# Altium schematic sheet lemoniot_battery.SchDoc
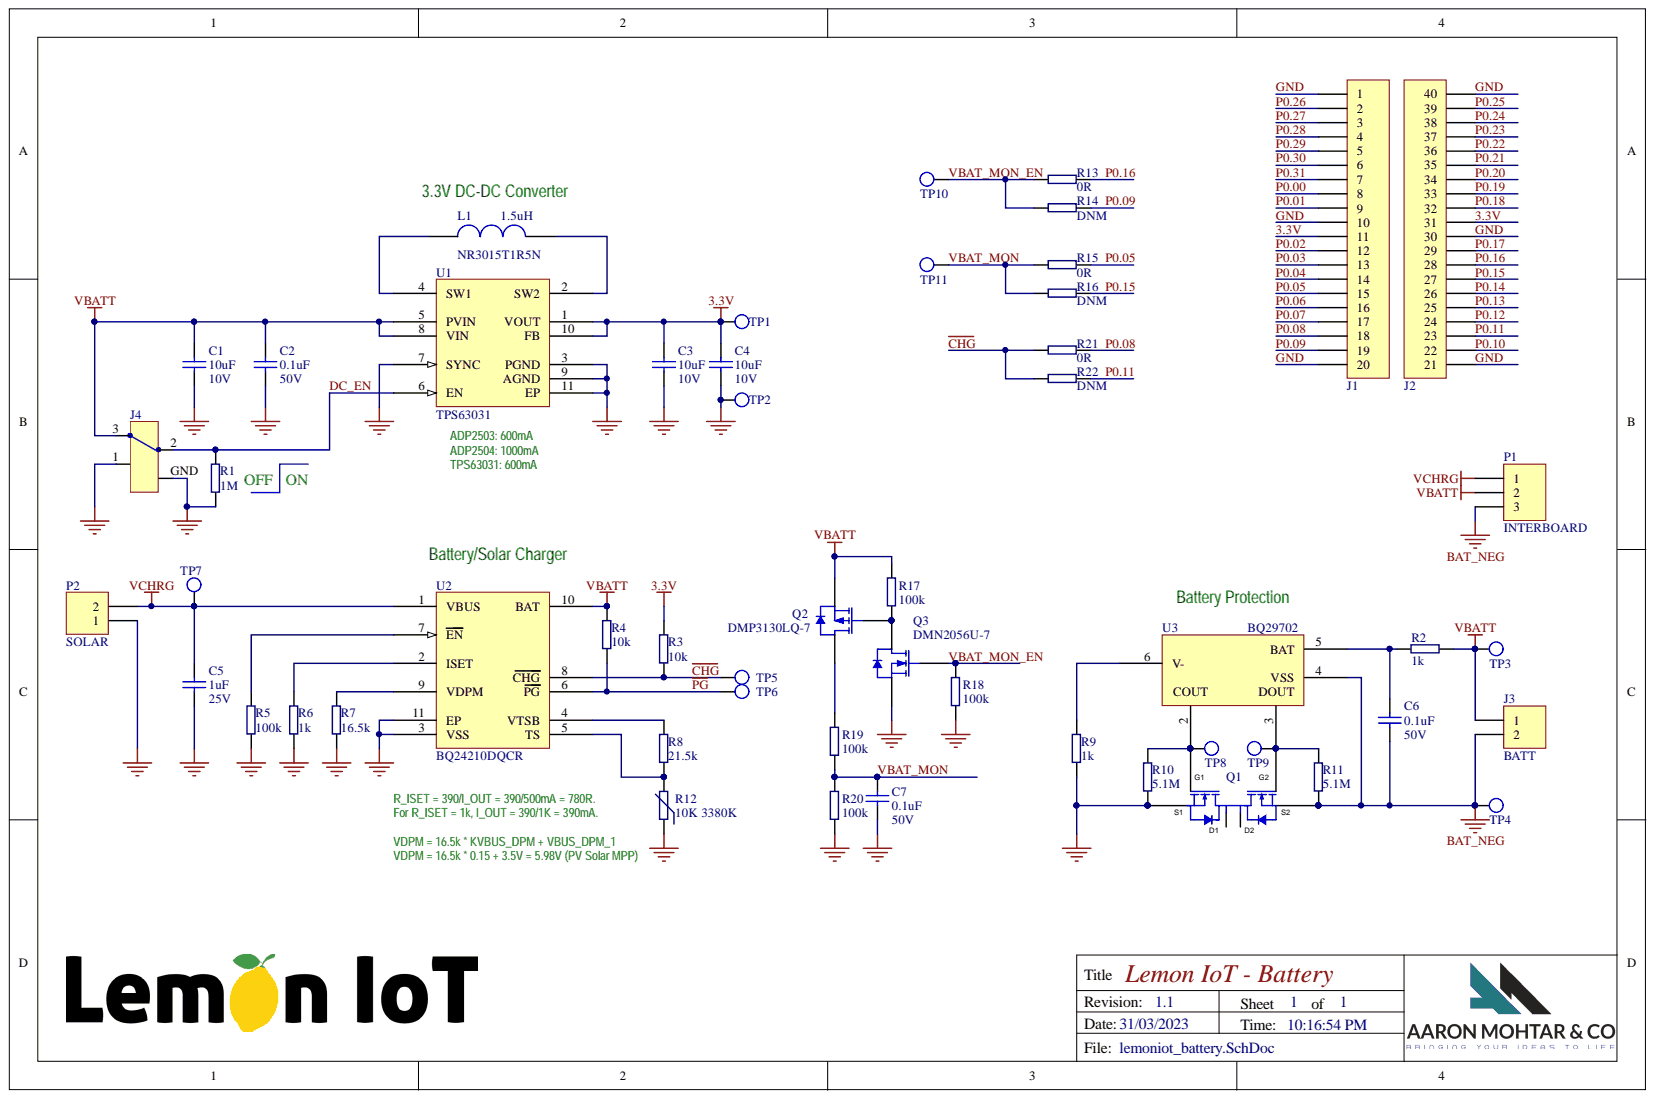
[diagram p0: lemoniot_battery.SchDoc]

## Parts
C1  10uF  Voltage: 10V  [CAPC0603(1608)100_N]
C2  0.1uF  Voltage: 50V  [CAPC0603(1608)100_N]
C3  10uF  Voltage: 10V  [CAPC0603(1608)100_N]
C4  10uF  Voltage: 10V  [CAPC0603(1608)100_N]
C5  1uF  Voltage: 25V  [CAPC0603(1608)100_N]
C6  0.1uF  Voltage: 50V  [CAPC0603(1608)100_N]
C7  value 0.1uF  Voltage: 50V  [CAPC0603(1608)100_N]  (hidden comment: 0.1uF 0603 50V)
J1  CONN RCPT 20POS 0.1 GOLD PCB  [SSQ-120-03-G-S]  (value not drawn)
J2  HDR 2.54 VERT SIL 20POS (B)  [SSQ-120-03-G-S-(B)]  (value not drawn)
J3  BATT  [S2B-PH-SM4-TB(LF)(SN)-2_V]
J4  SWITCH SLIDE SPDT 200MA 30V  [1825232-1]  (value not drawn)
L1  1.5uH  PartNumber: NR3015T1R5N  [TAYO-IND0315_V]
P1  INTERBOARD  [Conn header 3pin 0.1" TH RA (Molex)]
P2  SOLAR  [MOLEX SL 0705530001]
Q1  DMG9926  [FP-SOT26_SC74R-IPC_B]  (value not drawn)
Q2  DMP3130LQ-7  [FP-SOT23-IPC_B]
Q3  DMN2056U-7  [FP-SOT23-IPC_B]
R1  1M  [RESC0603(1608)_N]
R2  1k  [RESC0603(1608)_N]
R3  10k  [RESC0603(1608)_N]
R4  10k  [RESC0603(1608)_N]
R5  100k  [RESC0603(1608)_N]
R6  1k  [RESC0603(1608)_N]
R7  16.5k  [RESC0603(1608)_N]
R8  21.5k  [RESC0603(1608)_N]
R9  1k  [RESC0603(1608)_N]
R10  5.1M  [RESC0603(1608)_N]
R11  5.1M  [RESC0603(1608)_N]
R12  10K 3380K  [RESC0603(1608)_N]
R13  value 0R  [RESC0603(1608)_N]  (hidden comment: RES 0R 0603)
R14  value DNM  [RESC0603(1608)_N]  (hidden comment: RES 0R 0603)  (no BOM)
R15  value 0R  [RESC0603(1608)_N]  (hidden comment: RES 0R 0603)
R16  value DNM  [RESC0603(1608)_N]  (hidden comment: RES 0R 0603)  (no BOM)
R17  value 100k  [RESC0603(1608)_N]  (hidden comment: 100K 0603)
R18  value 100k  [RESC0603(1608)_N]  (hidden comment: 100K 0603)
R19  value 100k  [RESC0603(1608)_N]  (hidden comment: 100K 0603)
R20  value 100k  [RESC0603(1608)_N]  (hidden comment: 100K 0603)
R21  value 0R  [RESC0603(1608)_N]  (hidden comment: RES 0R 0603)
R22  value DNM  [RESC0603(1608)_N]  (hidden comment: RES 0R 0603)  (no BOM)
TP1  TestPoint_1  [Test Point - Small]  (value not drawn)  (no BOM)
TP2  TestPoint_1  [Test Point - Small]  (value not drawn)  (no BOM)
TP3  TestPoint_1  [Test Point - Small]  (value not drawn)  (no BOM)
TP4  TestPoint_1  [Test Point - Small]  (value not drawn)  (no BOM)
TP5  TestPoint_1  [Test Point - Small]  (value not drawn)  (no BOM)
TP6  TestPoint_1  [Test Point - Small]  (value not drawn)  (no BOM)
TP7  TestPoint_1  [Test Point - Small]  (value not drawn)  (no BOM)
TP8  TestPoint_1  [Test Point - Small]  (value not drawn)  (no BOM)
TP9  TestPoint_1  [Test Point - Small]  (value not drawn)  (no BOM)
TP10  TestPoint_1  [Test Point - Small]  (value not drawn)  (no BOM)
TP11  TestPoint_1  [Test Point - Small]  (value not drawn)  (no BOM)
U1  TPS63031  [ADI-CP-10-9_V]
U2  BQ24210DQCR  [SON50P300X200X80-11N]
U3  BQ29702  [FP-DSE0006A-IPC_C]

## Nets
BAT_NEG: C6.1 J3.2 P1.3 Q1.3 R11.1 TP4.0 U3.4(VSS)
VBATT: C1.2 C2.2 J3.1 J4.3 P1.2 Q2.2 R2.2 R4.2 R17.2 TP3.0 U1.5(PVIN) U1.8(VIN) U2.10(BAT)
VCHRG: C5.2 P1.1 P2.2 TP7.0 U2.1(VBUS)
3.3V: C3.2 C4.2 J1.11 J2.31 R3.2 TP1.0 U1.1(VOUT) U1.10(FB)
~{CHG}: R3.1 R21.1 R22.1 TP5.0 U2.8(CHG)
DC_EN: J4.2 R1.2 U1.6(EN)
GND: C1.1 C2.1 C3.1 C4.1 C5.1 C7.1 J1.1 J1.10 J1.20 J2.21 J2.30 J2.40 J4.1 J4.GND P2.1 Q1.1 Q3.2 R1.1 R5.1 R6.1 R7.1 R9.1 R10.1 R12.1 R18.1 R20.1 TP2.0 U1.3(PGND) U1.7(SYNC) U1.9(AGND) U1.11(EP) U2.3(VSS) U2.11(EP)
P0.00: J1.8
P0.01: J1.9
P0.02: J1.12
P0.03: J1.13
P0.04: J1.14
P0.05: J1.15 R15.2
P0.06: J1.16
P0.07: J1.17
P0.08: J1.18 R21.2
P0.09: J1.19 R14.2
P0.10: J2.22
P0.11: J2.23 R22.2
P0.12: J2.24
P0.13: J2.25
P0.14: J2.26
P0.15: J2.27 R16.2
P0.16: J2.28 R13.2
P0.17: J2.29
P0.18: J2.32
P0.19: J2.33
P0.20: J2.34
P0.21: J2.35
P0.22: J2.36
P0.23: J2.37
P0.24: J2.38
P0.25: J2.39
P0.26: J1.2
P0.27: J1.3
P0.28: J1.4
P0.29: J1.5
P0.30: J1.6
P0.31: J1.7
~{PG}: R4.1 TP6.0 U2.6(PG)
VBAT_MON: C7.2 R15.1 R16.1 R19.1 R20.2 TP11.0
VBAT_MON_EN: Q3.1 R13.1 R14.1 R18.2 TP10.0
NetC6_2: C6.2 R2.1 U3.5(BAT)
NetL1_1: L1.1 U1.4(SW1)
NetL1_2: L1.2 U1.2(SW2)
NetQ1_4: Q1.4 R11.2 TP9.0 U3.3
NetQ1_6: Q1.6 R10.2 TP8.0 U3.2
NetQ2_1: Q2.1 Q3.3 R17.1
NetQ2_3: Q2.3 R19.2
NetR5_2: R5.2 U2.7(EN)
NetR6_2: R6.2 U2.2(ISET)
NetR7_2: R7.2 U2.9(VDPM)
NetR8_1: R8.1 R12.2 U2.5(TS)
NetR8_2: R8.2 U2.4(VTSB)
NetR9_2: R9.2 U3.6(V-)

Not connected: Q1 2 5

## Library data of the parts
C1 C3 C4 = CAP 10uF 10V 0603: CAP CER 10UF 10V X5R 0603; Manufacturer: Taiyo Yuden; PartNumber: LMK107BBJ106MALT; DK code: 587-3258-1-ND; Component Kind: Standard; Library Name: Passives.SchLib; Operating temperature: -55°C ~ 85°C; PackageDescription: 0603; Temperature coefficient: X5R; Value: 10uF
C2 C6 = CAP 0.1uF 50V 0603: CAP CER 0.1UF 50V X7R 0603; Manufacturer: AVX Corporation; PartNumber: 06035C104KAT2A; DK code: 478-5052-1-ND; Component Kind: Standard; Library Name: Passives.SchLib; Operating temperature: -55°C ~ 125°C; PackageDescription: 0603; Temperature coefficient: X7R; Value: 0.1uF
C5 = CAP 1uF 25V 0603: CAP CER 1UF 25V X7R 0603; Manufacturer: Samsung Electro-Mechanics; PartNumber: CL10B105KA8NNNC; DK code: 1276-1184-1-ND; Component Kind: Standard; Library Name: Passives.SchLib; Operating temperature: -55°C ~ 125°C; PackageDescription: 0603; Temperature coefficient: X7R; Value: 1uF
C7 = CAP 0.1uF 25V 0603: CAP CER 0.1UF 50V X7R 0603; Manufacturer: AVX Corporation; PartNumber: 06035C104KAT2A; DK code: 478-5052-1-ND; Component Kind: Standard; Operating temperature: -55°C ~ 125°C; PackageDescription: 0603; Temperature coefficient: X7R; Library Name: TranspIR.SchLib
J1 = HDR 2.54 VERT SIL 20POS: Manufacturer: Samtec Inc.; DK code: SAM1198-20-ND; Component Kind: Standard; Description: CONN RCPT 20POS 0.1 GOLD PCB; Library Name: connectors.IntLib; PartNumber: SSQ-120-03-G-S
J2 = HDR 2.54 VERT SIL 20POS (B): CONN HEADER VERT 20POS 2.54MM; Manufacturer: Harwin Inc.; PartNumber: M20-9992046; DK code: 952-3306-ND; Component Kind: Standard; Library Name: connectors.IntLib
J3 = JST CONN HDR SMD R/A 2POS 2MM: CONN HEADER VERT 2POS 2.54MM; Manufacturer: JST Sales America Inc.; PartNumber: S2B-PH-SM4-TB(LF)(SN); DK code: 455-1749-1-ND; Component Kind: Standard; Library Name: Connectors.SchLib
J4 = 1825232-1: Slide Switch SPDT Through Hole, Right Angle; Manufacturer: TE Connectivity; DK code: A107673-ND; Component Kind: Standard; Library Name: battery.SchLib; PartNumber: 1825232-1
L1 = IND 1.5uH 1.82A: FIXED IND 1.5UH 1.82A 48MOHM SMD; Manufacturer: Taiyo Yuden; DK code: 587-2617-1-ND; Component Kind: Standard; Library Name: Passives.SchLib; Value: 1.5uH
P1 = CONN RCPT 3POS: CONN RCPT 3POS 0.1 GOLD; Manufacturer: Samtec Inc.; PartNumber: SSQ-120-03-G-S; DK code: SAM1198-20-ND; Component Kind: Standard; Library Name: lemoniot_battery.SchLib
P2 = MOLEX SL HDR 2.54 RA SIL 2POS: CONN HEADER R/A 2POS 2.54MM; Manufacturer: Molex, LLC; PartNumber: 0705530001; DK code: WM4900-ND; Component Kind: Standard; Library Name: Connectors.SchLib
Q1 = DMG9926UDM: MOSFET 2N-CH 20V 4.2A SOT-26; Manufacturer: Diodes Incorporated; PartNumber: DMG9926UDM-7; DK code: DMG9926UDMDITR-ND; Component Kind: Standard; Library Name: Discretes.SchLib; Package: SOT-26
Q2 = DMP3130LQ-7: MOSFET P-CH 30V 3.5A SOT23; Manufacturer: Diodes Incorporated; DK code: DMP3130LQ-7DICT-ND; Component Kind: Standard; PackageDescription: SOT23; PartNumber: DMP3130LQ-7; Library Name: Athena-TranspIR.SchLib
Q3 = DMN2056U-7: MOSFET N-CHANNEL 20V 4A SOT23-3; Manufacturer: Diodes Incorporated; DK code: DMN2056U-7DICT-ND; Component Kind: Standard; PackageDescription: SOT23-3; PartNumber: DMN2056U-7; Library Name: Athena-TranspIR.SchLib
R1 = RES 10K 0603: Tolerance: 1%; Component Kind: Standard; Library Name: Passives.SchLib; PackageDescription: 0603; Value: 1M
R2 R6 R9 = RES 1K 0603: RES SMD 1K OHM 1% 1/10W 0603; Manufacturer: Yageo; PartNumber: RC0603FR-071KL; DK code: 311-1.00KHRCT-ND; Component Kind: Standard; Library Name: Passives.SchLib; PackageDescription: 0603; Tolerance: 1%; Value: 1k
R3 R4 = RES 10K 0603: RES SMD 10K OHM 1% 1/10W 0603; Manufacturer: Yageo; PartNumber: RC0603FR-0710KL; DK code: 311-10.0KHRCT-ND; Component Kind: Standard; Library Name: Passives.SchLib; PackageDescription: 0603; Tolerance: 1%; Value: 10k
R5 = RES 100K 0603: RES SMD 100K OHM 1% 1/10W 0603; Manufacturer: Yageo; PartNumber: RC0603FR-07100KL; DK code: 311-100KHRCT-ND; Component Kind: Standard; Library Name: Passives.SchLib; PackageDescription: 0603; Tolerance: 1%; Value: 100k
R7 = RES 16.5K 0603: RES SMD 16.5K OHM 1% 1/10W 0603; Manufacturer: Yageo; PartNumber: RC0603FR-0716K5L; DK code: 311-16.5KHRCT-ND; Component Kind: Standard; Library Name: Passives.SchLib; PackageDescription: 0603; Tolerance: 1%; Value: 16.5k
R8 = RES 21.5K 0603: RES SMD 21.5K OHM 1% 1/10W 0603; Manufacturer: Yageo; PartNumber: RC0603FR-0721K5L; DK code: 311-21.5KHRCT-ND; Component Kind: Standard; Library Name: Passives.SchLib; PackageDescription: 0603; Tolerance: 1%; Value: 21.5k
R10 R11 = RES 5.1M 0603: RES SMD 5.1M OHM 1% 1/10W 0603; Manufacturer: Yageo; PartNumber: RC0603FR-075M1L; DK code: 13-RC0603FR-075M1LCT-ND; Component Kind: Standard; Library Name: Passives.SchLib; PackageDescription: 0603; Tolerance: 1%; Value: 5.1M
R12 = THERMISTOR NTC 10K 0603: THERMISTOR NTC 10KOHM 3380K 0603; Manufacturer: Murata Electronics; PartNumber: NCU18XH103F60RB; DK code: 490-16279-1-ND; Tolerance: 1%; Component Kind: Standard; Library Name: Passives.SchLib; PackageDescription: 0603; Value: 10K 3380K
R13-R16 R21 R22 = RES 0R 0603: RES SMD 0 OHM JUMPER 1/10W 0603; Manufacturer: Bourns Inc.; PartNumber: CR0603-J/-000ELF; DK code: CR0603-J/-000ELFTR-ND; PackageDescription: 0603; Library Name: amco-PnpLibrary.IntLib
  R13 R15 R21: Component Kind: Standard
R17-R20 = RES 100K 0603: RES SMD 100K OHM 1% 1/10W 0603; Manufacturer: Bourns Inc.; PartNumber: CR0603-FX-1003ELF; DK code: CR0603-FX-1003ELFCT-ND; Component Kind: Standard; PackageDescription: 0603; Tolerance: 1%; Library Name: TranspIR.SchLib
TP1-TP11 = TestPoint_1: Test Point; Library Name: other.IntLib
U1 = TPS63031: IC REG BCK BST 3.3V 900MA 10SON; Manufacturer: Texas Instruments; PartNumber: TPS63031DSKR; DK code: 296-39461-2-ND; Component Kind: Standard; Library Name: ICs.SchLib; PackageDescription: 10-WFDFN
U2 = BQ24210DQCR: IC BATT CHG LI-ION 1CELL 10WSON; Manufacturer: Texas Instruments; DK code: 296-BQ24210DQCRTR-ND; Component Kind: Standard; Package: WSON-10; PartNumber: BQ24210DQCR; Library Name: IntergratedCircuits.SchLib
U3 = BQ29702DSET: IC BATT PROT LI-ION 1CELL 6WSON; Manufacturer: Texas Instruments; DK code: 296-46885-1-ND; Component Kind: Standard; Library Name: ICs.SchLib; PackageDescription: 6WSON; PartNumber: BQ29702DSET
